# Altium schematic sheet Sheet1.SchDoc
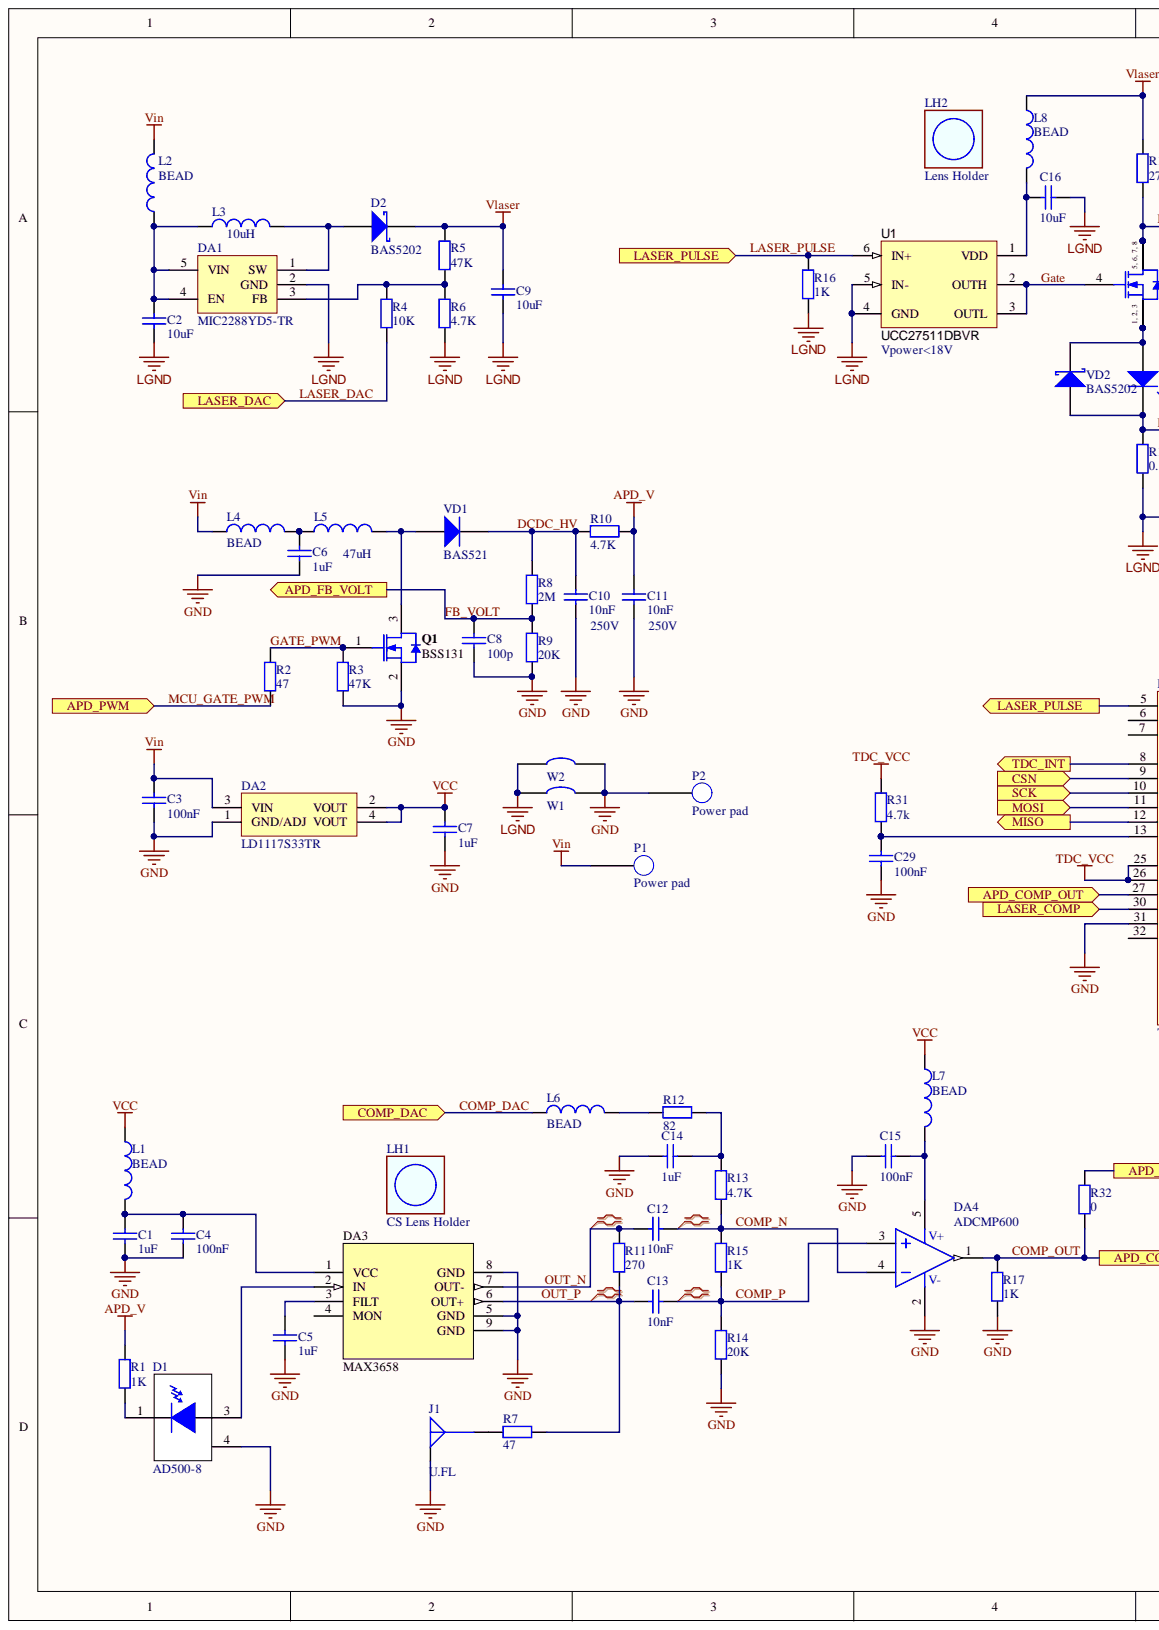
[diagram p0: Sheet1.SchDoc, left]
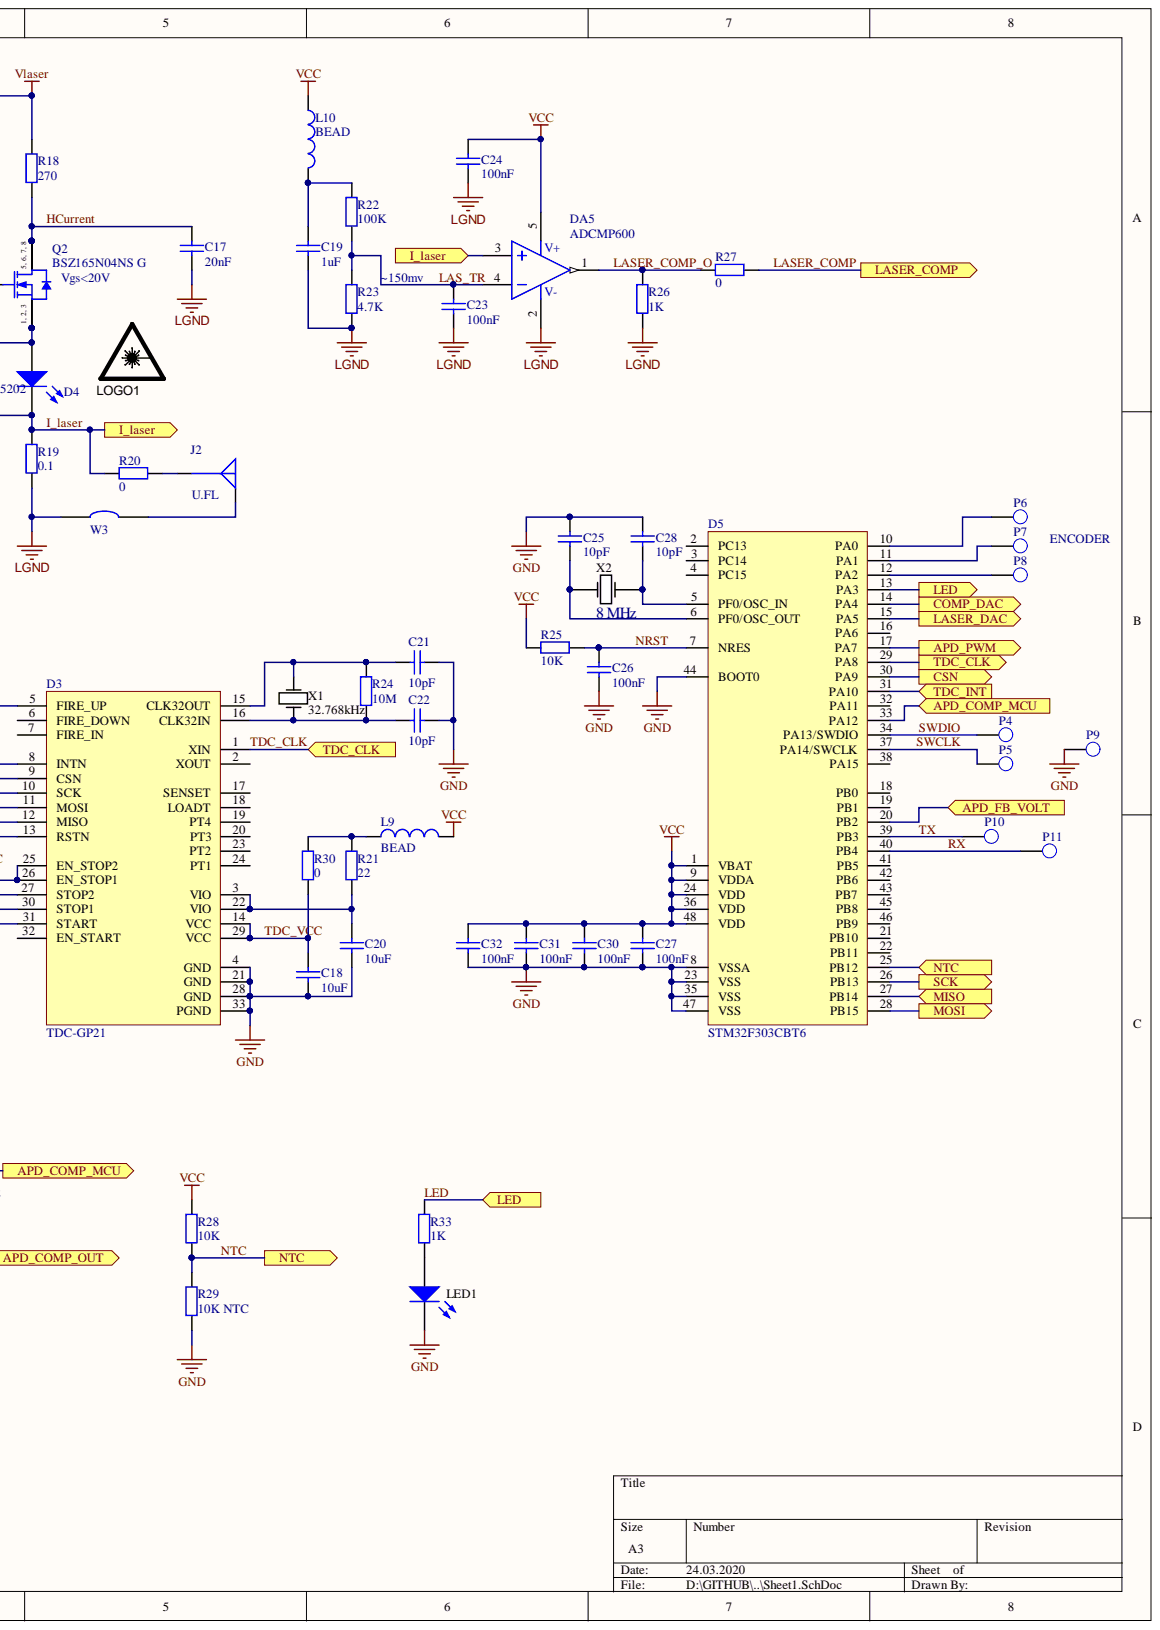
[diagram p1: Sheet1.SchDoc, right]

## Parts
C1  1uF  [CAPC2012X135N]
C2  10uF  [CAPC1608X90N]
C3  100nF  [CAPC1608X90N]
C4  100nF  [CAPC1608X90N]
C5  1uF  [CAPC1608X90N]
C6  1uF  [CAPC2012X135N]
C7  1uF  [CAPC2012X135N]
C8  100p  [CAPC1608X90N]
C9  10uF  [CAPC1608X90N]
C10  10nF  Voltage: 250V  [CAPC2012X135N]
C11  10nF  Voltage: 250V  [CAPC2012X135N]
C12  10nF  [CAPC1608X90N]
C13  10nF  [CAPC1608X90N]
C14  1uF  [CAPC2012X135N]
C15  100nF  [CAPC1608X90N]
C16  10uF  [CAPC1608X90N]
C17  20nF  [CAPC1608X90N]
C18  10uF  [CAPC1608X90N]
C19  1uF  [CAPC2012X135N]
C20  10uF  [CAPC1608X90N]
C21  10pF  [CAPC1608X90N]
C22  10pF  [CAPC1608X90N]
C23  100nF  [CAPC1608X90N]
C24  100nF  [CAPC1608X90N]
C25  10pF  [CAPC1608X90N]
C26  100nF  [CAPC1608X90N]
C27  100nF  [CAPC1608X90N]
C28  10pF  [CAPC1608X90N]
C29  100nF  [CAPC1608X90N]
C30  100nF  [CAPC1608X90N]
C31  100nF  [CAPC1608X90N]
C32  100nF  [CAPC1608X90N]
D1  AD500-8  [AD500-8]
D2  BAS5202  [SOD523F]
D3  TDC-GP21  [QFN32 5x5mm]
D4  Laser  [SPL_PL90]  (value not drawn)
D5  STM32F303CBT6  [LQFP-48_N]
DA1  MIC2288YD5-TR  [SOT23-5]
DA2  LD1117S33TR  [SOT223]
DA3  MAX3658  [TDFN-8_0.65]
DA4  ADCMP600  [SOT23-5]
DA5  ADCMP600  [SOT23-5]
J1  U.FL  [TE C-1909763]
J2  U.FL  [TE C-1909763]
L1  BEAD  [INDC1608N]
L2  BEAD  [INDC1608N]
L3  value 10uH  [V0805HD]  (hidden comment: Inductor)
L4  BEAD  [INDC1608N]
L5  value 47uH  [INDC3216]  (hidden comment: Inductor)
L6  BEAD  [INDC1608N]
L7  BEAD  [INDC1608N]
L8  BEAD  [INDC1608N]
L9  BEAD  [INDC1608N]
L10  BEAD  [INDC1608N]
LED1  LED  [LED_0603]
LH1  CS Lens Holder  [Lens Holder CS]
LH2  Lens Holder  [LENS_HOLDER_20MM_ADJ]
LOGO1  LASER RADIATION  [LaserWarning_Small]  (value not drawn)  (no BOM)
P1  Power pad  [Ext_power_pad]
P2  Power pad  [Ext_power_pad]
P4  Pad  [Ext_pad_2]  (value not drawn)
P5  Pad  [Ext_pad_2]  (value not drawn)
P6  Pad  [Ext_pad_2]  (value not drawn)
P7  Pad  [Ext_pad_2]  (value not drawn)
P8  Pad  [Ext_pad_2]  (value not drawn)
P9  Pad  [Ext_pad_2]  (value not drawn)
P10  Pad  [Ext_pad_2]  (value not drawn)
P11  Pad  [Ext_pad_2]  (value not drawn)
Q1  BSS131  [INF-PG-SOT23_N]
Q2  BSZ165N04NS G  [INF-PG-TSDSON-8-1_A_V]
R1  1K  [RESC1608X55N]
R2  47  [RESC1608X55N]
R3  47K  [RESC1608X55N]
R4  10K  [RESC1608X55N]
R5  47K  [RESC1608X55N]
R6  4.7K  [RESC1608X55N]
R7  47  [RESC1608X55N]
R8  2M  [RESC2012X70N]
R9  20K  [RESC1608X55N]
R10  4.7K  [RESC1608X55N]
R11  270  [RESC1608X55N]
R12  82  [RESC1608X55N]
R13  4.7K  [RESC1608X55N]
R14  20K  [RESC2012X70N]
R15  1K  [RESC1608X55N]
R16  1K  [RESC1608X55N]
R17  1K  [RESC1608X55N]
R18  270  [RESC1608X55N]
R19  0.1  [RESC2012X70N]
R20  0  [RESC1608X55N]
R21  22  [RESC1608X55N]
R22  100K  [RESC1608X55N]
R23  4.7K  [RESC1608X55N]
R24  10M  [RESC1608X55N]
R25  10K  [RESC1608X55N]
R26  1K  [RESC1608X55N]
R27  0  [RESC1608X55N]
R28  10K  [RESC1608X55N]
R29  10K NTC  [RESC1608X55N]
R30  0  [RESC1608X55N]
R31  4.7k  [RESC1608X55N]
R32  0  [RESC1608X55N]
R33  1K  [RESC1608X55N]
U1  UCC27511DBVR  [SOT23-6_M]
VD1  BAS521  [SOD523F]
VD2  BAS5202  [SOD523F]
W1  NET connector  [Net connector]  (value not drawn)
W2  NET connector  [Net connector]  (value not drawn)
W3  NET connector  [Net connector]  (value not drawn)
X1  32.768kHz  [QUARTZ_32K]
X2  value 8 MHz  [SX-6 QUARTZ]  (hidden comment: Quartz)

## Ports
APD_COMP_MCU, APD_COMP_OUT, APD_FB_VOLT, APD_PWM, COMP_DAC, CSN, I_LASER, LASER_COMP, LASER_DAC, LASER_PULSE, LED, MISO, MOSI, NTC, SCK, TDC_CLK, TDC_INT

## Nets
APD_V: C11.2 R1.2 R10.1
GND: C1.1 C3.1 C4.1 C5.1 C6.2 C7.1 C8.2 C10.1 C11.1 C14.2 C15.2 C18.1 C20.1 C21.1 C22.1 C25.2 C26.1 C27.1 C28.2 C29.1 C30.1 C31.1 C32.1 D1.4 D3.4(GND) D3.21(GND) D3.28(GND) D3.31(START) D3.33(PGND) D5.8(VSSA) D5.23(VSS) D5.35(VSS) D5.44(BOOT0) D5.47(VSS) DA2.1(GND/ADJ) DA3.5(GND) DA3.8(GND) DA3.9(GND) DA4.2 J1.G LED1.2 P2.1 P9.1 Q1.2 R3.2 R9.2 R14.1 R17.1 R29.1 W1.2 W2.2
LGND: C2.1 C9.1 C16.1 C17.1 C19.1 C23.1 C24.1 DA1.2(GND) DA5.2 R6.1 R16.1 R19.1 R23.2 R26.1 U1.4(GND) U1.5(IN-) W1.1 W2.1 W3.1
VCC: C7.2 C24.2 C27.2 C30.2 C31.2 C32.2 D5.1(VBAT) D5.9(VDDA) D5.24(VDD) D5.36(VDD) D5.48(VDD) DA2.2(VOUT) DA2.4(VOUT) DA5.5 L1.1 L7.1 L9.2 L10.1 R25.1 R28.1
VIN: C3.2 DA2.3(VIN) L2.2 L4.1 P1.1
VLASER: C9.2 D2.K L8.1 R5.2 R18.2
APD_COMP_MCU: D5.33(PA12) R32.1 ; port APD_COMP_MCU
COMP_DAC: D5.14(PA4) L6.1 ; port COMP_DAC
COMP_N: C12.1 DA4.4 R13.1 R15.2
COMP_OUT: D3.27(STOP2) DA4.1 R17.2 R32.2 ; port APD_COMP_OUT
COMP_P: C13.1 DA4.3 R14.2 R15.1
CSN: D3.9(CSN) D5.30(PA9) ; port CSN
DCDC_HV: C10.2 R8.2 R10.2 VD1.K
FB_VOLT: C8.1 D5.20(PB2) R8.1 R9.1 ; port APD_FB_VOLT
GATE: Q2.4 U1.2(OUTH) U1.3(OUTL)
GATE_PWM: Q1.1 R2.2 R3.1
HCURRENT: C17.2 Q2.5 Q2.6 Q2.7 Q2.8 R18.1
I_LASER: D4.K DA5.3 R19.2 R20.2 VD2.A ; port I_LASER
LAS_TR: C23.2 DA5.4 R22.2 R23.1
LASER_COMP: D3.30(STOP1) R27.2 ; port LASER_COMP
LASER_COMP_O: DA5.1 R26.2 R27.1
LASER_DAC: D5.15(PA5) R4.1 ; port LASER_DAC
LASER_PULSE: D3.5(FIRE_UP) R16.2 U1.6(IN+) ; port LASER_PULSE
LED: D5.13(PA3) R33.2 ; port LED
MCU_GATE_PWM: D5.17(PA7) R2.1 ; port APD_PWM
MISO: D3.12(MISO) D5.27(PB14) ; port MISO
MOSI: D3.11(MOSI) D5.28(PB15) ; port MOSI
NRST: C26.2 D5.7(NRES) R25.2
NTC: D5.25(PB12) R28.2 R29.2 ; port NTC
OUT_N: C12.2 DA3.7(OUT-) R11.2
OUT_P: C13.2 DA3.6(OUT+) R7.2 R11.1
RX: D5.40(PB4) P11.1
SCK: D3.10(SCK) D5.26(PB13) ; port SCK
SWCLK: D5.37(PA14/SWCLK) P5.1
SWDIO: D5.34(PA13/SWDIO) P4.1
TDC_CLK: D3.1(XIN) D5.29(PA8) ; port TDC_CLK
TDC_INT: D3.8(INTN) D5.31(PA10) ; port TDC_INT
TDC_VCC: C18.2 D3.14(VCC) D3.25(EN_STOP2) D3.26(EN_STOP1) D3.29(VCC) R30.2 R31.1
TX: D5.39(PB3) P10.1
NetC1_2: C1.2 C4.2 DA3.1(VCC) L1.2
NetC2_2: C2.2 DA1.4(EN) DA1.5(VIN) L2.1 L3.1
NetC5_2: C5.2 DA3.3(FILT)
NetC6_1: C6.1 L4.2 L5.1
NetC14_1: C14.1 R12.1 R13.2
NetC15_1: C15.1 DA4.5 L7.2
NetC16_2: C16.2 L8.2 U1.1(VDD)
NetC19_2: C19.2 L10.2 R22.1
NetC20_2: C20.2 D3.3(VIO) D3.22(VIO) R21.2
NetC21_2: C21.2 D3.15(CLK32OUT) R24.2 X1.1
NetC22_2: C22.2 D3.16(CLK32IN) R24.1 X1.2
NetC25_1: C25.1 D5.6(PF0/OSC_OUT) X2.1
NetC28_1: C28.1 D5.5(PF0/OSC_IN) X2.3
NetC29_2: C29.2 D3.13(RSTN) R31.2
NetD1_1: D1.1 R1.1
NetD1_3: D1.3 DA3.2(IN)
NetD2_A: D2.A DA1.1(SW) L3.2
NetD4_A: D4.A Q2.1 Q2.2 Q2.3 VD2.K
NetD5_10: D5.10(PA0) P6.1
NetD5_11: D5.11(PA1) P7.1
NetD5_12: D5.12(PA2) P8.1
NetDA1_3: DA1.3(FB) R4.2 R5.1 R6.2
NetJ1_S: J1.S R7.1
NetJ2_G: J2.G W3.2
NetJ2_S: J2.S R20.1
NetL5_2: L5.2 Q1.3 VD1.A
NetL6_2: L6.2 R12.2
NetL9_1: L9.1 R21.1 R30.1
NetLED1_1: LED1.1 R33.1

Not connected: D3 2(XOUT) 6(FIRE_DOWN) 7(FIRE_IN) 17(SENSET) 18(LOADT) 19(PT4) 20(PT3) 23(PT2) 24(PT1) 32(EN_START); D5 2(PC13) 3(PC14) 4(PC15) 16(PA6) 18(PB0) 19(PB1) 21(PB10) 22(PB11) 32(PA11) 38(PA15) 41(PB5) 42(PB6) 43(PB7) 45(PB8) 46(PB9); DA3 4(MON)

## Library data of the parts
C1-C9 C12-C32 = CC0805: Chip capacitor; Voltage: 25V; Component Kind: Standard; Library Name: MyLibSch.SchLib; Series: X7R; Signal Integrity: Cap; Simulation: CAP
  C1 C5-C7 C14 C19: Value: 1uF
  C2 C9 C16 C18 C20: Value: 10uF
  C3 C4 C15 C23 C24 C26 C27 C29-C32: Value: 100nF
  C8: Value: 100p
  C12 C13: Value: 10nF
  C17: Value: 20nF
  C21 C22 C25 C28: Value: 10pF
C10 C11 = CC0805: Chip capacitor; Component Kind: Standard; Library Name: MyLibSch.SchLib; Series: X7R; Signal Integrity: Cap; Simulation: CAP; Value: 10nF
D1 = AD500-8: APD photodiode; Component Kind: Standard; Library Name: lcd_lib.SchLib
D2 VD2 = D Schottky: Schottky Diode; Code_JEDEC: DO-214-AA; Component Kind: Standard; LatestRevisionDate: 17-Jul-2002; LatestRevisionNote: Re-released for DXP Platform.; Library Name: Miscellaneous Devices.IntLib; PackageDescription: DO-214-AA/SMB; 2 C-Bend Leads; Body 5.3 x 3.6 mm, inc. leads (LxW); PackageReference: SMB; Published: 8-Jun-2000; Signal Integrity: D Schottky; Simulation: SKYDIODE; Publisher: Altium Limited
D3 = TDC_GP21: TDC; Component Kind: Standard; Library Name: lcd_lib.SchLib
D4 = TO-18 Laser: Component Kind: Standard; Description: TO-18 Laser; Library Name: lcd_lib.SchLib
D5 = STM32F3_LQFP48: Microcontroller; Component Kind: Standard; Library Name: lcd_lib.SchLib
DA1 = MIC2288: Step Up; FB_voltage: 1.24V; Max Volt: 10V; Component Kind: Standard; Library Name: lcd_lib.SchLib
DA2 = LD1117S: LOW DROP FIXED AND ADJUSTABLE  POSITIVE VOLTAGE REGULATORS; Component Kind: Standard; Created data: 2011-08-03; Library Name: MyLibSch.SchLib; Value: LD1117S33TR
DA3 = MAX3658: Transimpedance Amp; Part Number: AD7278; Component Kind: Standard; Library Name: lcd_lib.SchLib
DA4 DA5 = ADCMP600: Fast comparator; Component Kind: Standard; Library Name: lcd_lib.SchLib
J1 J2 = RF Connector - SMA: Component Kind: Standard; Library Name: RF Connector.SchLib
L1 L2 L4 L6-L10 = BEAD: Ferrit Bead; Component Kind: Standard; Created data: 2010-10-16; Library Name: MyLibSch.SchLib; Value: BEAD
L3 L5 = Inductor: Code_IEC: 1005; Code_JEITA: 0402; Component Kind: Standard; Description: Inductor; LatestRevisionDate: 29-May-2009; LatestRevisionNote: IPC-7351 Footprint Added.; Library Name: Miscellaneous Devices.IntLib; PackageDescription: Chip Inductor; PackageReference: 0402-A; Published: 8-Jun-2000; Signal Integrity: Inductor; Simulation: INDUCTOR; Publisher: Altium Limited
LED1 = LED_0603: Component Kind: Standard; Description: LED_0603; Library Name: lcd_lib.SchLib
LH1 = CS Lens Holder: M12 Lens Holder; Component Kind: Standard; Library Name: lcd_lib.SchLib
LH2 = M12 Lens Holder: Component Kind: Standard; Description: M12 Lens Holder; Library Name: lcd_lib.SchLib
LOGO1 = Laser_Warning: Laser Warning Symbol; SymbolCreator: a0412235; LatestRevisionDate: 2016-03-21; Published: 2009-03-03; SymbolVersion: 8/13/2013; Library Name: Logos.IntLib; Publisher: Texas Instruments
P1 P2 = Power_pad: Pad for wire; Component Kind: Standard; Library Name: lcd_lib.SchLib
P4-P11 = Ext Pad: Pad for wire; Component Kind: Standard; Library Name: lcd_lib.SchLib
Q1 = BSS131: N-Channel SIPMOS Small-Signal-Transistor, 240 V VDS, 0.11 A ID, -55 to 150 degC, PG-SOT23, Reel, Green; Manufacturer: Infineon; Green: TRUE; ID (max): 0.11 A; IDpuls (max): 0.4 A; Ptot (max): 0.36 W; QG: 2.1 nC; RDS (on) (max): 14,000 mOhm; RDS (on) (max) (@4.5V): 20,000 mOhm; RthJA (max): 350 K/W; VDS (max): 240 V; VGS(th) (max): 1.8 V; VGS(th) (min): 0.8 V; Component Kind: Standard; ComponentLink1Description: Manufacturer URL; ComponentLink1URL: http://www.infineon.com/; ComponentLink2Description: Datasheet; ComponentLink2URL: http://www.infineon.com/dgdl/BSS131_V2.6+G.pdf?folderId=db3a3043156fd573011622e10b5c1f67&fileId=db3a304330f68606013104bf65993eeb; ComponentLink3Description: Package Specification; ComponentLink3URL: http://www.infineon.com/dgdl/SOT23-Package_Overview.pdf?folderId=db3a30431936bc4b0119532290053c66&fileId=db3a30431936bc4b0119533468123c85; DatasheetVersion: Rev. 2.6; Halogen-free: TRUE; Mounting Technology: Surface Mount; PackageDescription: 3-Pin Small Outline Transistor Package, 2.9 x 1.3 mm Body, 0.95 mm Pitch; PackageReference: PG-SOT23; PackageVersion: Apr-08; Packing: Reel; PartNumber: BSS131; Supplier 1: Farnell; Supplier 2: Farnell; Supplier 3: Newark; Supplier Part Number 1: 1056524RL; Supplier Part Number 2: 1056524; Supplier Part Number 3: 96K7109
Q2 = BSZ165N04NS G: N-Channel OptiMOS 3 Power-Transistor, 40 V VDS, 31 A ID, -55 to 150 degC, PG-TSDSON-8-1, Reel, Green; Manufacturer: Infineon; Green: TRUE; ID (max): 31 A; IDpuls (max): 124 A; Polarity: N; Ptot (max): 25 W; QG: 7.8 nC; RDS (on) (max): 16.5 mOhm; Rth: 5 K/W; RthJA (max): 60 K/W; VDS (max): 40 V; VGS(th) (max): 4 V; VGS(th) (min): 2 V; Component Kind: Standard; ComponentLink1Description: Manufacturer URL; ComponentLink1URL: http://www.infineon.com/; ComponentLink2Description: Datasheet; ComponentLink2URL: http://www.infineon.com/dgdl/BSZ165N04NSG_rev2.pdf?folderId=db3a3043156fd5730115c7d50620107c&fileId=db3a30431689f4420116c48a64960872; ComponentLink3Description: Package Specification; ComponentLink3URL: http://www.infineon.com/dgdl/BSZ100N06LS3_rev2.3.pdf?folderId=db3a30431ddc9372011ebafa04517f8b&fileId=db3a30431ddc9372011ebb132c97000a; DatasheetVersion: Rev. 2.0; Halogen-free: TRUE; Library Name: BSZ165N04NS G.SchLib; Mounting Technology: Surface Mount; PackageDescription: 8-Pin Plastic (Green) Thin Dual Small Outline Non-Leaded Package, 3.3 x 3.3 mm Body, 0.65 mm Pitch; PackageReference: PG-TSDSON-8-1; PackageVersion: Rev. 01, 03/2007; Packing: Reel; PartNumber: BSZ165N04NS G; RthJC (max): 5 K/W; Supplier 1: Digi-Key; Supplier 2: Digi-Key; Supplier 3: Digi-Key; Supplier 4: Farnell; Supplier 5: Newark; Supplier 6: Mouser; Supplier Part Number 1: BSZ165N04NSGINTR-ND; Supplier Part Number 2: BSZ165N04NSGINCT-ND; Supplier Part Number 3: BSZ165N04NSGINDKR-ND; Supplier Part Number 4: 1775508; Supplier Part Number 5: 60R2545; Supplier Part Number 6: 726-BSZ165N04NSG
R1-R33 = RC0805: Chip resistor; Tolerance: 5%; Component Kind: Standard; Library Name: MyLibSch.SchLib; Signal Integrity: Res; Simulation: RESISTOR
  R1 R15-R17 R26 R33: Value: 1K
  R2 R7: Value: 47
  R3 R5: Value: 47K
  R4 R25 R28: Value: 10K
  R6 R10 R13 R23: Value: 4.7K
  R8: Value: 2M
  R9 R14: Value: 20K
  R11 R18: Value: 270
  R12: Value: 82
  R19: Value: 0.1
  R20 R27 R30 R32: Value: 0
  R21: Value: 22
  R22: Value: 100K
  R24: Value: 10M
  R29: Value: 10K NTC
  R31: Value: 4.7k
U1 = UCC27511DBVR: Single-Channel High-Speed Low-Side Gate Driver (with 4-A Peak Source and 8-A Peak Sink), DBV0006A; Alternate Manufacturer: Texas Instruments; Alternate PartNumber: UCC27511DBVT; CompType: IC; SymbolCreator: a0411947; U/M: EA; Component Kind: Standard; ComponentLink1Description: Datasheet Link; ComponentLink1URL: http://www.ti.com/lit/ds/symlink/ucc27511.pdf; LatestRevisionDate: 2015-09-01; Library Name: TI_Pre_Release.IntLib; Manufacturer: Texas Instruments; MountType: SMT; PackageReference: DBV0006A; PartNumber: UCC27511DBVR; RoHS: Y; SymbolVersion: 2013-08-02; Publisher: Texas Instruments
VD1 = DIODE 2 pin: Component Kind: Standard; Created data: 2011-07-16; Library Name: MyLibSch.SchLib; Value: BAS521
X1 = Quartz_32k: Quartz; Component Kind: Standard; Library Name: lcd_lib.SchLib
X2 = Quartz_SX-6: Quartz_SX-6 (SMD06035C4); Component Kind: Standard; Library Name: lcd_lib.SchLib
W1-W3 = NET connector: Component Kind: Net Tie; Library Name: lcd_lib.SchLib
